# Altium schematic sheet SignalConditioningShield.SchDoc(SignalConditioningShield)
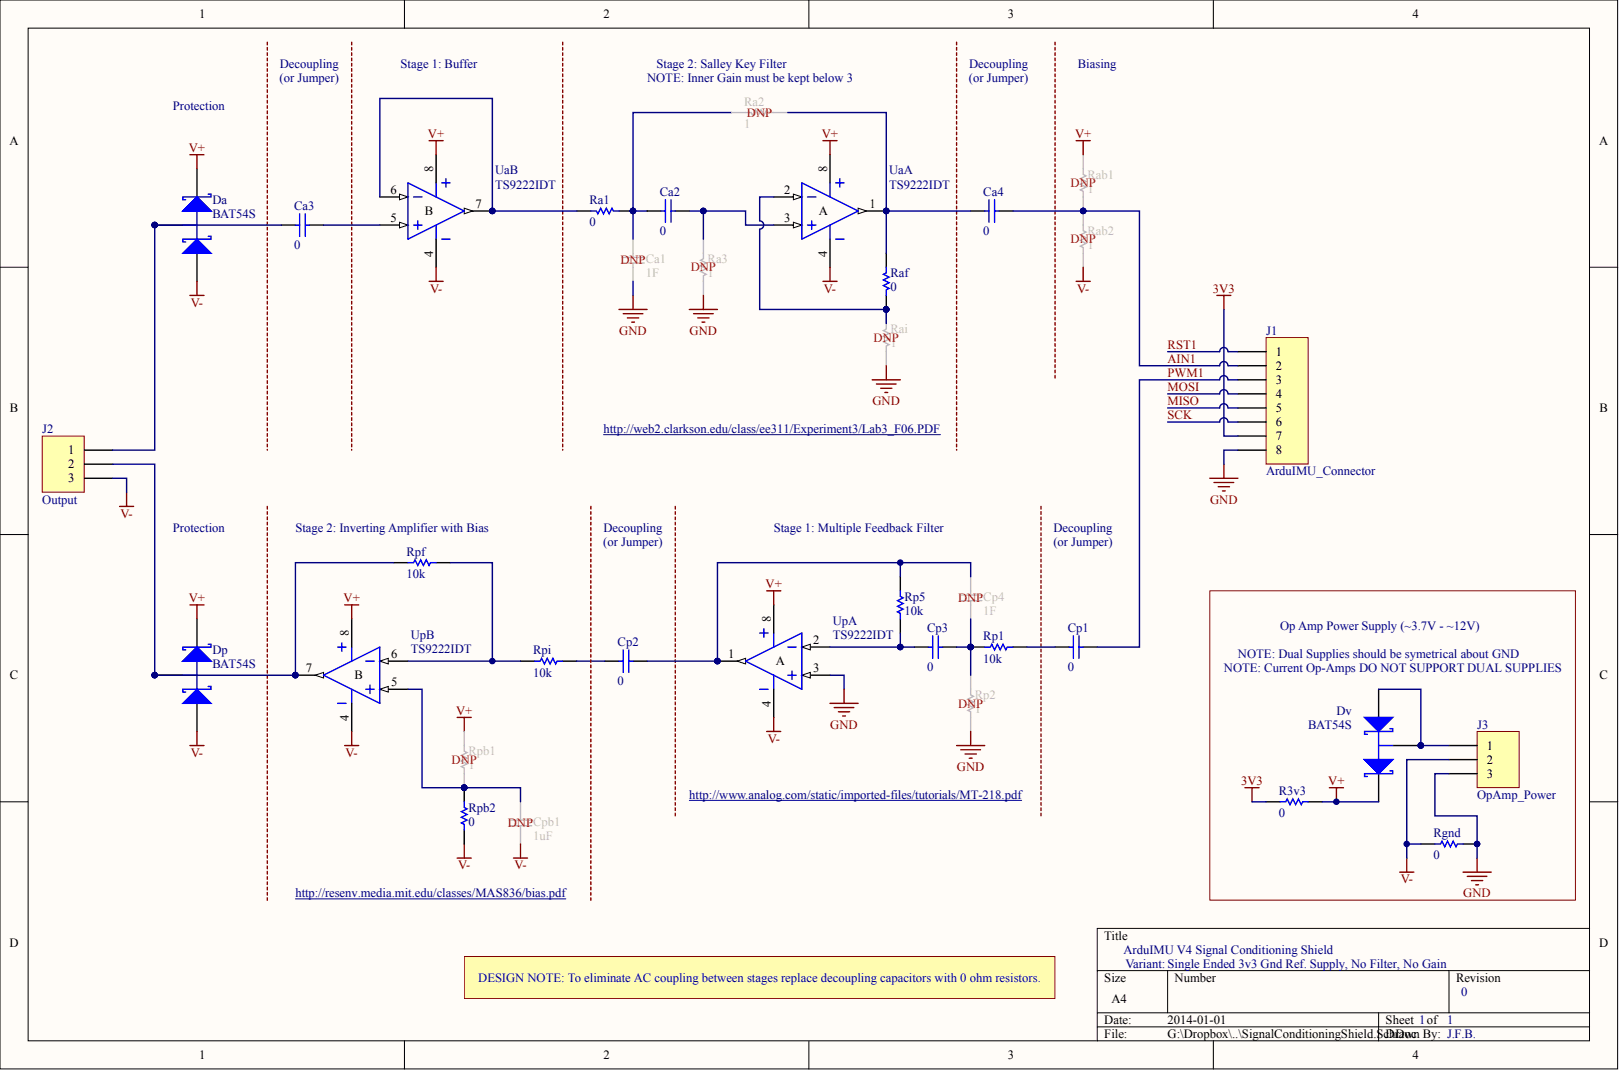
[diagram p0: SignalConditioningShield.SchDoc]

## Parts
Ca1  value 1F  (hidden comment: Cap Semi)
Ca2  Cap Semi  (value not drawn)
Ca3  Cap Semi  (value not drawn)
Ca4  Cap Semi  (value not drawn)
Cp1  Cap Semi  (value not drawn)
Cp2  Cap Semi  (value not drawn)
Cp3  Cap Semi  (value not drawn)
Cp4  value 1F  (hidden comment: Cap Semi)
Cpb1  value 1uF  (hidden comment: Cap Semi)
Da  BAT54S
Dp  BAT54S
Dv  BAT54S
J1  ArduIMU_Connector
J2  Output
J3  OpAmp_Power
R3v3  0
Ra1  0
Ra2  1
Ra3  1
Rab1  1
Rab2  1
Raf  1  (value not drawn)
Rai  1
Rgnd  0
Rp1  0  (value not drawn)
Rp2  1
Rp5  1
Rpb1  1
Rpb2  1  (value not drawn)
Rpf  1
Rpi  1
Ua  TS9222IDT  (parts A,B)
Up  TS9222IDT  (parts A,B)

## Nets
3V3: J1.7 R3v3.2
GND: Ca1.1 J1.8 J3.3 Ra3.2 Rai.2 Rgnd.2 Rp2.2 Up.3
V+: Da.2 Dp.2 Dv.2 R3v3.1 Rab1.2 Rpb1.2 Ua.8 Up.8
V-: Cpb1.2 Da.1 Dp.1 J2.3 J3.2 Rab2.1 Rgnd.1 Rpb2.1 Ua.4 Up.4
AIN1: Ca4.1 J1.2 Rab1.1 Rab2.2
MISO: J1.5
MOSI: J1.4
PWM1: Cp1.2 J1.3
RST1: J1.1
SCK: J1.6
NetCa1_2: Ca1.2 Ca2.2 Ra1.2 Ra2.1
NetCa2_1: Ca2.1 Ra3.1 Ua.3
NetCa3_1: Ca3.1 Da.3 J2.1
NetCa3_2: Ca3.2 Ua.5
NetCa4_2: Ca4.2 Ra2.2 Raf.1 Ua.1
NetCp1_1: Cp1.1 Rp1.1
NetCp2_1: Cp2.1 Rpi.2
NetCp2_2: Cp2.2 Cp4.1 Rp5.2 Up.1
NetCp3_1: Cp3.1 Rp5.1 Up.2
NetCp3_2: Cp3.2 Cp4.2 Rp1.2 Rp2.1
NetCpb1_1: Cpb1.1 Rpb1.1 Rpb2.2 Up.5
NetDp_3: Dp.3 J2.2 Rpf.2 Up.7
NetDv_1: Dv.1 Dv.3 J3.1
NetRa1_1: Ra1.1 Ua.6 Ua.7
NetRaf_2: Raf.2 Rai.1 Ua.2
NetRpf_1: Rpf.1 Rpi.1 Up.6

## Library data of the parts
Ca2 Cp3 = (no symbol name): Value: 1F; Published: 8-Jun-2000; LatestRevisionDate: 29-May-2009; LatestRevisionNote: IPC-7351 Footprint Added.; PackageReference: C1206; PackageDescription: Chip Capacitor; Publisher: Altium Limited
Ca3 Ca4 Cp1 Cp2 = (no symbol name): Value: 100uF; Published: 8-Jun-2000; LatestRevisionDate: 29-May-2009; LatestRevisionNote: IPC-7351 Footprint Added.; PackageReference: C1206; PackageDescription: Chip Capacitor; Publisher: Altium Limited
R3v3 Rgnd = (no symbol name): Note: If flow soldering, adjust pad width of PCB Footprint to 0.7 - 0.8 times the width of the resistor.; Published: 17-Dec-2003; LatestRevisionDate: 19-Mar-2004; LatestRevisionNote: Silkscreen size reduced.; PackageDocument: Mar-2003; PackageReference: 6-0805; Code_IPC: 2012 [0805]; ComponentLink1Description: Manufacturer Link; ComponentLink1URL: http://www.panasonic.com/industrial/components/resistive_products.htm; ComponentLink2Description: Datasheet; ComponentLink2URL: http://www.panasonic.com/industrial/components/pdf/AOA0000CE1.pdf; Publisher: Altium Limited
Ca1 Cp4 Cpb1 Da Dp Dv J1-J3 Ra1-Ra3 Rab1 Rab2 Raf Rai Rp1 Rp2 Rp5 Rpb1 Rpb2 Rpf Rpi Ua Up = (no symbol name)
  Ca1 Cp4 Cpb1: Published: 8-Jun-2000; LatestRevisionDate: 29-May-2009; LatestRevisionNote: IPC-7351 Footprint Added.; PackageReference: C1206; PackageDescription: Chip Capacitor
  Da Dp Dv: Published: 17-Sep-2003; LatestRevisionDate: 12-Dec-2006; LatestRevisionNote: IPC-7351 Footprint Added.; DatasheetVersion: 4-Mar-2002; PackageVersion: May-1999; PackageReference: SOT23; PackageDescription: 3-Pin SOT-23 Package 0.95 mm Pitch, 2.3 mm Lead Span; ComponentLink1Description: Manufacturer Link; ComponentLink1URL: http://www.semiconductors.philips.com/; ComponentLink2Description: Datasheet; ComponentLink2URL: http://www.semiconductors.philips.com/acrobat/datasheets/BAT54_SERIES_4.pdf
  J1-J3: LatestRevisionDate: 17-Jul-2002; LatestRevisionNote: Re-released for DXP Platform.
  Ra1-Ra3 Rab1 Rab2 Raf Rai Rp1 Rp2 Rp5 Rpb1 Rpb2 Rpf Rpi: Published: 17-Dec-2003; LatestRevisionDate: 19-Mar-2004; LatestRevisionNote: Silkscreen size reduced.; PackageDocument: Mar-2003; PackageReference: 8-1206; Code_IPC: 3216 [1206]; ComponentLink1Description: Manufacturer Link; ComponentLink1URL: http://www.panasonic.com/industrial/components/resistive_products.htm; ComponentLink2Description: Datasheet; ComponentLink2URL: http://www.panasonic.com/industrial/components/pdf/AOA0000CE2.pdf
  Ca1 Cp4 Cpb1 Da Dp Dv J1-J3 Ra1-Ra3 Rab1 Rab2 Raf Rai Rp1 Rp2 Rp5 Rpb1 Rpb2 Rpf Rpi: Publisher: Altium Limited
